# Altium schematic sheet Communication.SchDoc(Communication)
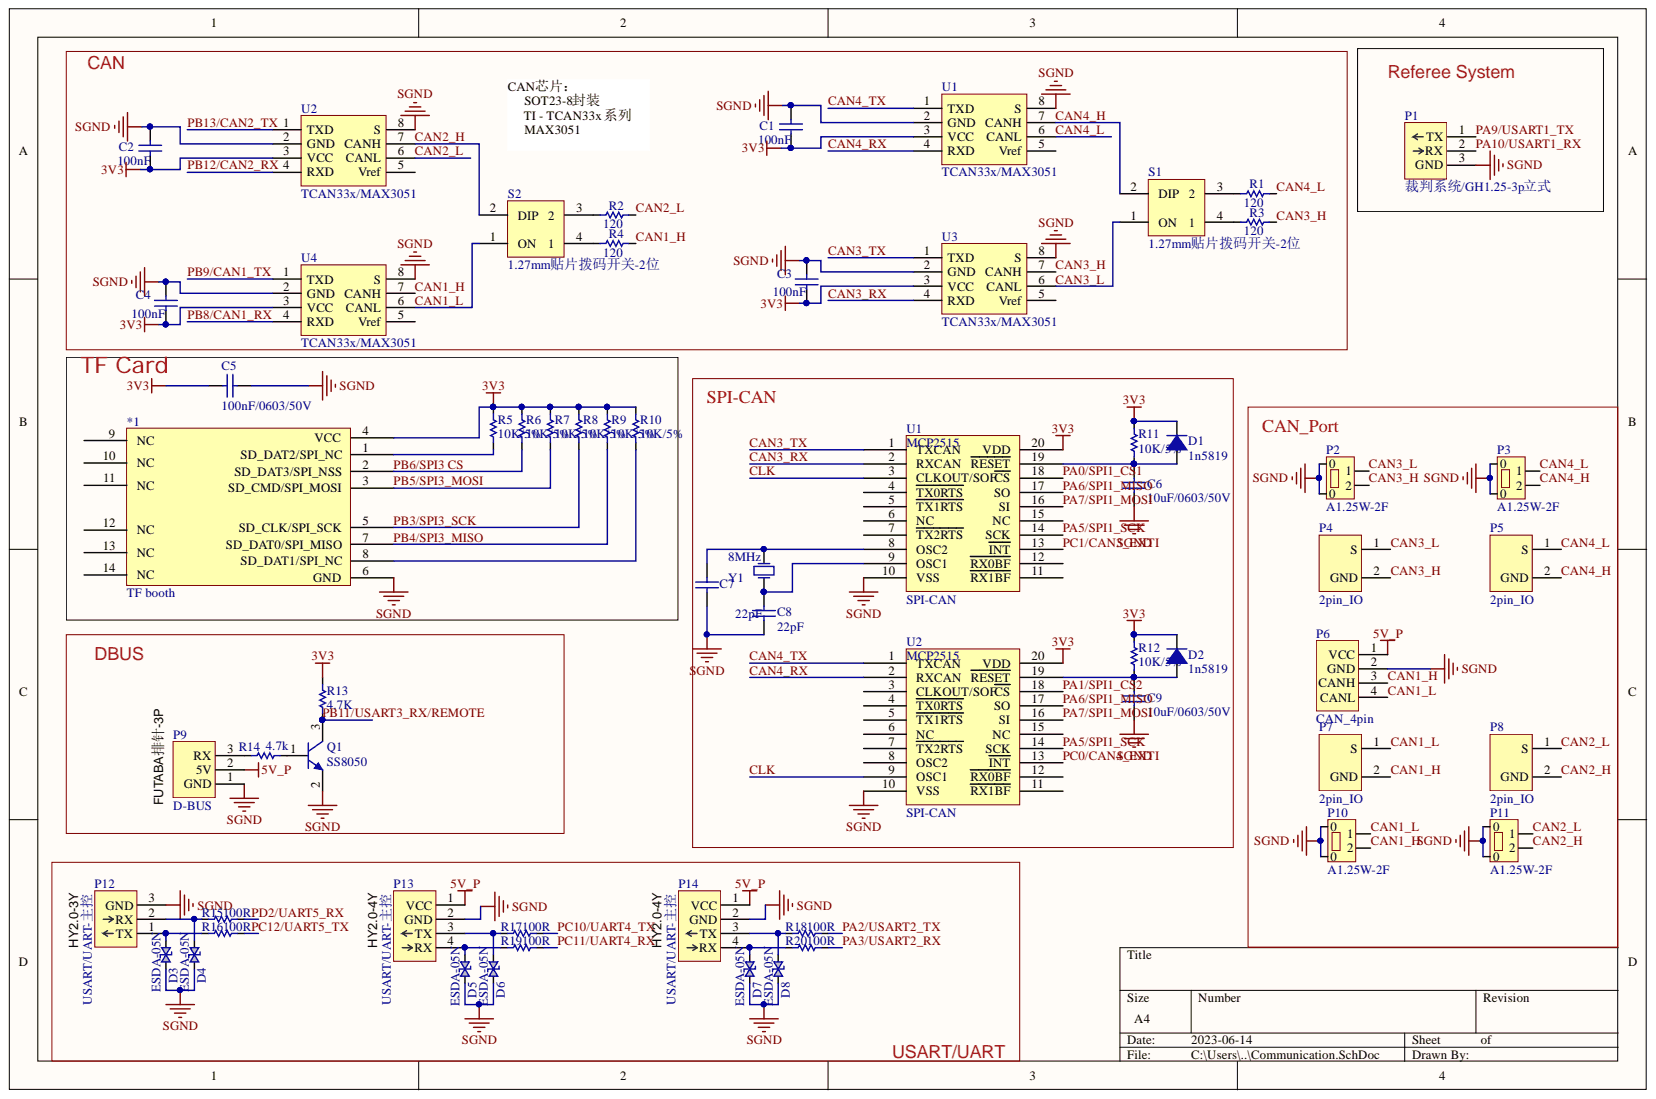
[diagram p0: Communication.SchDoc]

## Parts
*1  TF booth  [tf_booth]
C1  100nF  [0603C]
C2  100nF  [0603C]
C3  100nF  [0603C]
C4  100nF  [0603C]
C5  100nF/0603/50V  [0603C]
C6  10uF/0603/50V  [0603C]
C7  22pF  [0603C]
C8  22pF  [0603C]
C9  10uF/0603/50V  [0603C]
D1  1n5819  [SOD-323-]
D2  1n5819  [SOD-323-]
D3  ESDA-05N  [0603ESDA]
D4  ESDA-05N  [0603ESDA]
D5  ESDA-05N  [0603ESDA]
D6  ESDA-05N  [0603ESDA]
D7  ESDA-05N  [0603ESDA]
D8  ESDA-05N  [0603ESDA]
P1  裁判系统/GH1.25-3p立式  [A1254-03AWB]
P2  A1.25W-2F  [GH1.25-2P-立贴]
P3  A1.25W-2F  [GH1.25-2P-立贴]
P4  2pin_IO  [HY2.00MM 2X1_立式]
P5  2pin_IO  [HY2.00MM 2X1_立式]
P6  CAN_4pin  [HY2.00MM 4X1立式]
P7  2pin_IO  [HY2.00MM 2X1_立式]
P8  2pin_IO  [HY2.00MM 2X1_立式]
P9  D-BUS  [HY2.00MM 3x1立式]
P10  A1.25W-2F  [GH1.25-2P-立贴]
P11  A1.25W-2F  [GH1.25-2P-立贴]
P12  USART/UART-主控  [HY2.00MM 3x1立式]
P13  USART/UART-主控  [HY2.00MM 4X1立式]
P14  USART/UART-主控  [HY2.00MM 4X1立式]
Q1  SS8050  [SOT-23-3]
R1  120  [0603R]
R2  120  [0603R]
R3  120  [0603R]
R4  120  [0603R]
R5  10K/5%  [0603R]
R6  10K/5%  [0603R]
R7  10K/5%  [0603R]
R8  10K/5%  [0603R]
R9  10K/5%  [0603R]
R10  10K/5%  [0603R]
R11  10K/5%  [0603R]
R12  10K/5%  [0603R]
R13  4.7K  [0603R]
R14  4.7k  [0603R]
R15  100R  [0603R]
R16  100R  [0603R]
R17  100R  [0603R]
R18  100R  [0603R]
R19  100R  [0603R]
R20  100R  [0603R]
S1  1.27mm贴片拨码开关-2位  [SW_DIP_2x2]
S2  1.27mm贴片拨码开关-2位  [SW_DIP_2x2]
U1  SPI-CAN  [TSSOP20]
U1  TCAN33x/MAX3051  [SOT-23-8]
U2  TCAN33x/MAX3051  [SOT-23-8]
U2  SPI-CAN  [TSSOP20]
U3  TCAN33x/MAX3051  [SOT-23-8]
U4  TCAN33x/MAX3051  [SOT-23-8]
Y1  8MHz  [NX3225GD]

## Nets
3V3: *1.4(VCC) C1.1 C2.1 C3.1 C4.1 C5.2 D1.2 D2.2 R5.2 R6.2 R7.2 R8.2 R9.2 R10.2 R11.2 R12.2 R13.2 U1.3 U1.20(VDD) U2.3 U2.20(VDD) U3.3(VCC) U4.3(VCC)
5V_P: P6.1(VCC) P9.2 P13.1(VCC) P14.1(VCC)
SGND: *1.6(GND) C1.2 C2.2 C3.2 C4.2 C5.1 C6.1 C7.1 C8.1 C9.1 D3.1 D4.1 D5.1 D6.1 D7.1 D8.1 P1.3 P2.0 P3.0 P6.2(GND) P9.1 P10.0 P11.0 P12.3 P13.2(GND) P14.2(GND) Q1.2 U1.2 U1.8 U1.10(VSS) U2.2 U2.8 U2.10(VSS) U3.2(GND) U3.8(S) U4.2(GND) U4.8(S)
CAN1_H: P6.3(CANH) P7.2 P10.2 R4.1 U4.7(CANH)
CAN1_L: P6.4(CANL) P7.1 P10.1 S2.1(ON) U4.6(CANL)
CAN2_H: P8.2 P11.2 S2.2(DIP) U2.7
CAN2_L: P8.1 P11.1 R2.1 U2.6
CAN3_H: P2.2 P4.2 R3.1 U3.7(CANH)
CAN3_L: P2.1 P4.1 S1.1(ON) U3.6(CANL)
CAN3_RX: U1.2 U3.4(RXD)
CAN3_TX: U1.1 U3.1(TXD)
CAN4_H: P3.2 P5.2 S1.2(DIP) U1.7
CAN4_L: P3.1 P5.1 R1.1 U1.6
CAN4_RX: U1.4 U2.2
CAN4_TX: U1.1 U2.1
CLK: U1.3 U2.9(OSC1)
PA0/SPI1_CS1: U1.18(CS)
PA1/SPI1_CS2: U2.18(CS)
PA2/USART2_TX: R18.2
PA3/USART2_RX: R20.2
PA5/SPI1_SCK: U1.14(SCK) U2.14(SCK)
PA6/SPI1_MISO: U1.17(SO) U2.17(SO)
PA7/SPI1_MOSI: U1.16(SI) U2.16(SI)
PA9/USART1_TX: P1.1
PA10/USART1_RX: P1.2
PB3/SPI3_SCK: *1.5(SD_CLK/SPI_SCK) R8.1
PB4/SPI3_MISO: *1.7(SD_DAT0/SPI_MISO) R9.1
PB5/SPI3_MOSI: *1.3(SD_CMD/SPI_MOSI) R7.1
PB6/SPI3 CS: *1.2(SD_DAT3/SPI_NSS) R6.1
PB8/CAN1_RX: U4.4(RXD)
PB9/CAN1_TX: U4.1(TXD)
PB11/USART3_RX/REMOTE: Q1.3 R13.1
PB12/CAN2_RX: U2.4
PB13/CAN2_TX: U2.1
PC0/CAN4_EXTI: U2.13(INT)
PC1/CAN3_EXTI: U1.13(INT)
PC10/UART4_TX: R17.2
PC11/UART4_RX: R19.2
PC12/UART5_TX: R16.2
PD2/UART5_RX: R15.2
Net*1_1: *1.1(SD_DAT2/SPI_NC) R5.1
Net*1_8: *1.8(SD_DAT1/SPI_NC) R10.1
NetC6_2: C6.2 D1.1 R11.1 U1.19(RESET)
NetC7_2: C7.2 U1.8 Y1.2
NetC8_2: C8.2 U1.9(OSC1) Y1.1
NetC9_2: C9.2 D2.1 R12.1 U2.19(RESET)
NetD3_2: D3.2 P12.1 R16.1
NetD4_2: D4.2 P12.2 R15.1
NetD5_2: D5.2 P13.4(RX) R19.1
NetD6_2: D6.2 P13.3(TX) R17.1
NetD7_2: D7.2 P14.4(RX) R20.1
NetD8_2: D8.2 P14.3(TX) R18.1
NetP9_3: P9.3 R14.1
NetQ1_1: Q1.1 R14.2
NetR1_2: R1.2 S1.3
NetR2_2: R2.2 S2.3
NetR3_2: R3.2 S1.4
NetR4_2: R4.2 S2.4

Not connected: *1 9(NC) 10(NC) 11(NC) 12(NC) 13(NC) 14(NC); U1 5 11(RX1BF) 12(RX0BF) 15(NC); U2 5 11(RX1BF) 12(RX0BF) 15(NC); U3 5(Vref); U4 5(Vref)

## Library data of the parts
C1-C9 = Cap: Capacitor; Value: 100pF; Simulation: CAP; Signal Integrity: Cap; Published: 8-Jun-2000; PackageReference: RAD-0.3; PackageDescription: Radial Cap, Thru-Hole; 2 Leads; 0.3 in Pin Spacing; LatestRevisionNote: Re-released for DXP Platform.; LatestRevisionDate: 17-Jul-2002; Component Kind: Standard; Publisher: Altium Limited
  C1-C4: Library Name: MyComponents_v2.5.IntLib
  C5-C9: Library Name: MyComponents_v2.5.SchLib
D1 D2 = Diode: Default Diode; Simulation: DIODE; Signal Integrity: Diode; Published: 8-Jun-2000; PackageVersion: Sep-1996; PackageReference: SMC; PackageDescription: DO-214-AB/SMC; 2 C-Bend Leads; Body 7.9 x 5.9 mm, inc. leads (LxW); Library Name: MyComponents_v2.5.SchLib; LatestRevisionNote: Re-released for DXP Platform.; LatestRevisionDate: 17-Jul-2002; Component Kind: Standard; Code_JEDEC: DO-214-AB; Publisher: Altium Limited
D3-D8 = ESDA: Library Name: MyComponents_v2.5.IntLib; Component Kind: Standard
P1 = UART_3pin: Library Name: MyComponents_v2.5.IntLib; Component Kind: Standard
P2 P3 P10 P11 = GH1.25-2P: Component Kind: Standard
  P2 P3: Library Name: MyComponents_v2.5.SchLib
  P10 P11: Library Name: MyComponents_v2.5.IntLib
P6 = CAN_4pin: CAN 4pin，带5V电源，HY2.0-4A; Library Name: MyComponents_v2.5.IntLib; Component Kind: Standard
P12 = UART_3pin_主控: Reference: UART_3pin_主控; Library Name: MyComponents_v2.5.IntLib; Component Kind: Standard
P13 P14 = UART_4pin_主控: 带电源串口; Library Name: MyComponents_v2.5.IntLib; Component Kind: Standard
Q1 = NPN: NPN Bipolar Transistor; Simulation: NPN; Signal Integrity: NPN; Published: 8-Jun-2000; PackageReference: TO-226-AA; PackageDescription: TO, Flat Index; 3 In-Line, Axial Leads; Body Dia. 4.8mm; Leads 0.55 x 0.51 mm (max); Library Name: MyComponents_v2.5.IntLib; LatestRevisionNote: Re-released for DXP Platform.; LatestRevisionDate: 17-Jul-2002; Component Kind: Standard; Code_JEDEC: TO-226-AA; Publisher: Altium Limited
R1-R20 = Res1: Resistor; Value: 1K; Simulation: RESISTOR; Signal Integrity: Res; Published: 8-Jun-2000; PackageReference: AXIAL-0.3; PackageDescription: Axial Device, Thru-Hole; 2 Leads; 0.3 in Pin Spacing; LatestRevisionNote: Re-released for DXP Platform.; LatestRevisionDate: 17-Jul-2002; Component Kind: Standard; Publisher: Altium Limited
  R1-R4 R13-R20: Library Name: MyComponents_v2.5.IntLib
  R5-R12: Library Name: MyComponents_v2.5.SchLib
S1 S2 = SW_DIP 2: Library Name: MyComponents_v2.5.IntLib; Component Kind: Standard
U1 U2 = MCP2515: Library Name: MCP2512.SchLib; Component Kind: Standard
U1-U4 = CAN: Library Name: MyComponents_v2.5.IntLib; Component Kind: Standard
Y1 = XTAL: Crystal Oscillator; Simulation: XTAL; Published: 8-Jun-2000; PackageReference: R38; PackageDescription: Crystal, Thru-Hole; 2 Leads; Body 3.1 x 8.2 mm (Dia.xH); Library Name: MyComponents_v2.5.IntLib; LatestRevisionNote: Re-released for DXP Platform.; LatestRevisionDate: 17-Jul-2002; Component Kind: Standard; Publisher: Altium Limited
*1 = TF booth: Library Name: MyComponents_v2.5.SchLib; Component Kind: Standard
P4 P5 P7 P8 = 2pin_IO: Library Name: MyComponents_v2.5.SchLib; Component Kind: Standard
P9 = D-BUS: Library Name: MyComponents_v2.5.IntLib; Component Kind: Standard
